# Altium schematic sheet Power_Sensing.SchDoc(Power_Sensing)
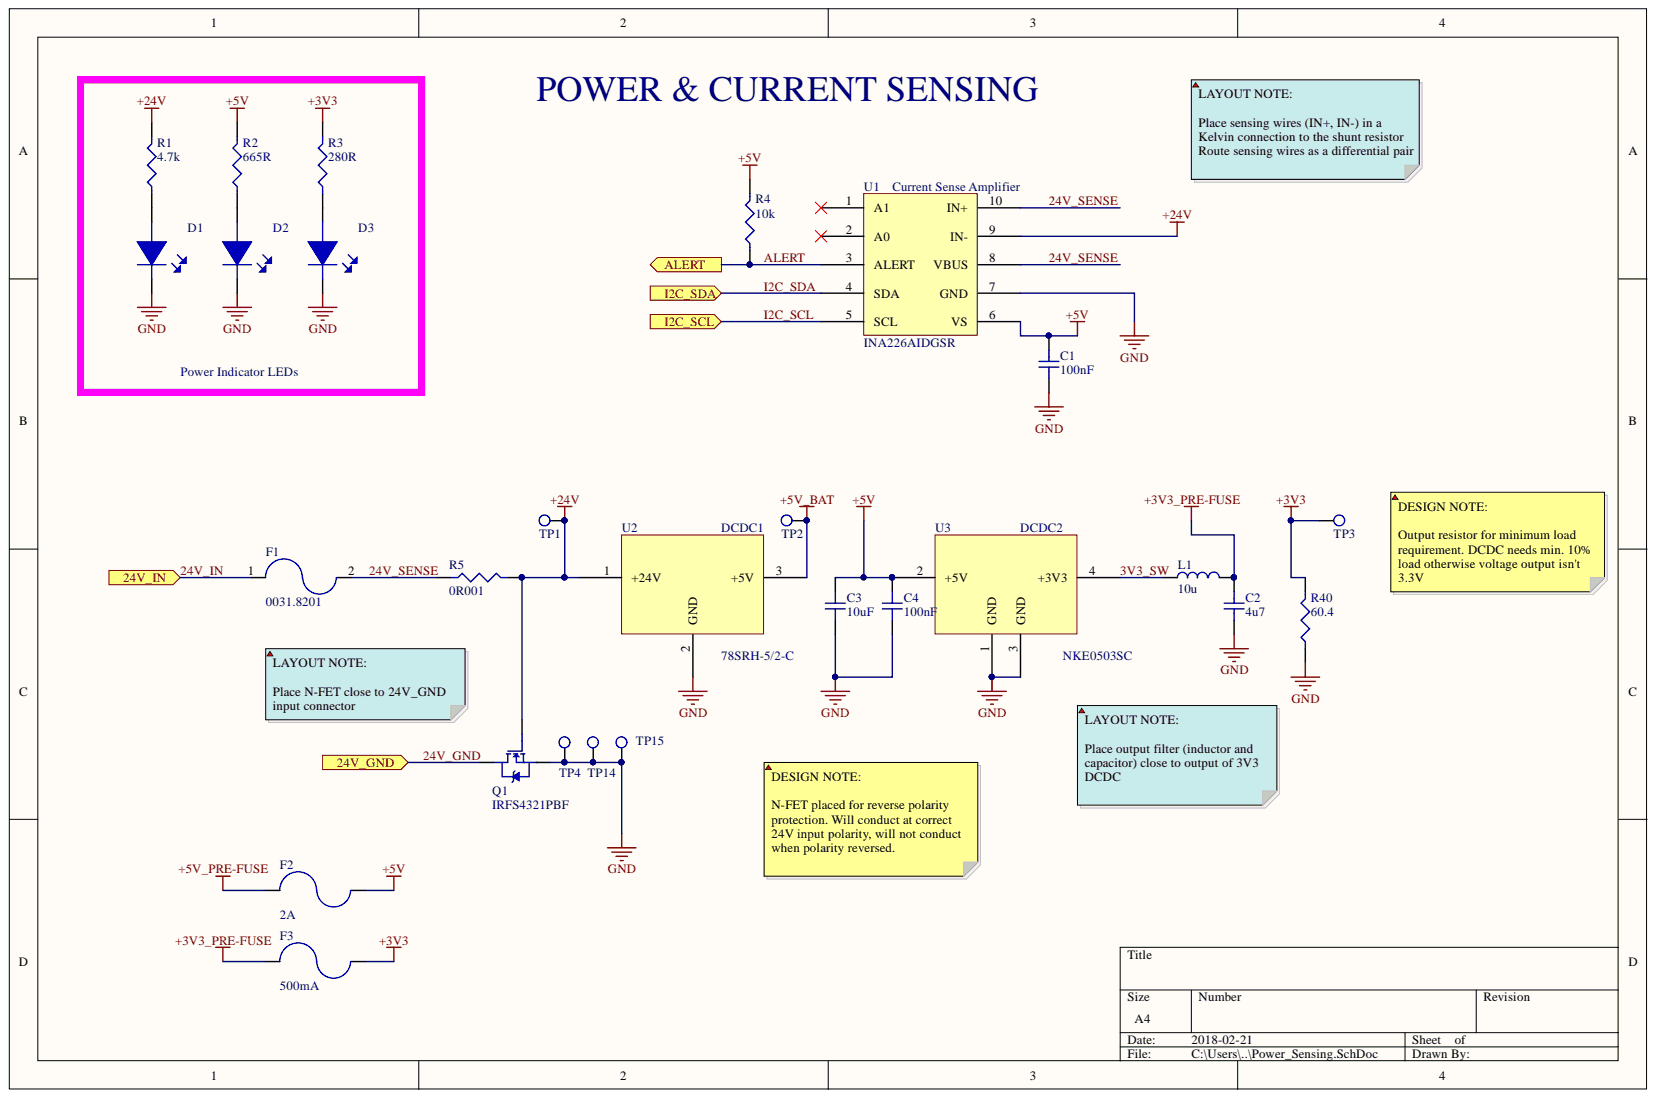
[diagram p0: Power_Sensing.SchDoc]

## Parts
C1  100nF  [C0805]
C2  4u7  [C0805]
C3  10uF  [TANT_10u]
C4  100nF  [C0805]
D1  LTST-C191KGKT  [LED_0603]  (value not drawn)
D2  LTST-C191KGKT  [LED_0603]  (value not drawn)
D3  LTST-C191KGKT  [LED_0603]  (value not drawn)
F1  0031.8201  [FUSE_HOLDER]
F2  2A  [FUSE_0603]
F3  500mA  [FUSE_0603]
L1  10u  [INDUCTOR]
Q1  IRFS4321PBF  [D2PAK_FETs]
R1  4.7k  [R0805]
R2  665R  [R0805]
R3  280R  [R0805]
R4  10k  [R0805]
R5  0R001  [R2512]
R40  60.4  [R0805]
TP1  5015  [TP_HOOK]  (value not drawn)
TP2  5015  [TP_HOOK]  (value not drawn)
TP3  5015  [TP_HOOK]  (value not drawn)
TP4  5015  [TP_HOOK]  (value not drawn)
TP14  5015  [TP_HOOK]  (value not drawn)
TP15  5015  [TP_HOOK]  (value not drawn)
U1  INA226AIDGSR  [10-VSSOP_INA226]
U2  78SRH-5/2-C  [DCDC1]
U3  NKE0503SC  [DCDC2]

## Ports
24V_GND, 24V_IN, ALERT, I2C_SCL, I2C_SDA

## Nets
+3V3: F3.2 R3.2 R40.2 TP3.1
+3V3_PRE-FUSE: C2.2 F3.1 L1.2
+5V: C1.2 C3.1 C4.2 F2.2 R2.2 R4.2 U1.6(VS) U3.2(+5V)
+5V_BAT: TP2.1 U2.3
+5V_PRE-FUSE: F2.1
+24V: Q1.1 R1.2 R5.2 TP1.1 U1.9(IN-) U2.1
GND: C1.1 C2.1 C3.2 C4.1 D1.2 D2.2 D3.2 Q1.3 R40.1 TP4.1 TP14.1 TP15.1 U1.7(GND) U2.2 U3.1(GND) U3.3(GND)
3V3_SW: L1.1 U3.4(+3V3)
24V_GND: Q1.4 ; port 24V_GND
24V_IN: F1.1 ; port 24V_IN
24V_SENSE: F1.2 R5.1 U1.8(VBUS) U1.10(IN+)
ALERT: R4.1 U1.3(ALERT) ; port ALERT
I2C_SCL: U1.5(SCL) ; port I2C_SCL
I2C_SDA: U1.4(SDA) ; port I2C_SDA
NetD1_1: D1.1 R1.1
NetD2_1: D2.1 R2.1
NetD3_1: D3.1 R3.1

Not connected: U1 1(A1) 2(A0)

## Library data of the parts
C1 C4 = GRM21B7U1A104JA01L: CAP MLCC 100nF 10Volts U2J 5%; Manufacturer: Murata; Voltage Rating (DC): 10V; Width: 0.049inch; Voltage Rating: 10V; Tolerance: 5%; Thickness: 0.053inch; Termination: SMD/SMT; Supplier Part Number 1: 490-11592-6-ND; Supplier 1: Digi-Key; Stock 1: 6000; Radiation Hardening: No; Pricing 1: 1=0.46, 10=0.32, 100=0.192, 500=0.144, 1000=0.1216 (USD); Packaging: Tape and Reel; Package Quantity: 3000; Mount: Surface Mount; Min Operating Temperature: -55°C; Max Operating Temperature: 125°C; Manufacturer Part Number: GRM21B7U1A104JA01L; Length: 2mm; Height: 1.25mm; Dielectric: U2J; Depth: 1.25mm; ComponentLink3URL: https://datasheet.ciiva.com/11618/product-11618924.pdf; ComponentLink3Description: https://datasheet.ciiva.com/11618/product-11618924.pdf; ComponentLink2URL: https://datasheet.ciiva.com/17451/product-17451845.pdf; ComponentLink2Description: https://datasheet.ciiva.com/17451/product-17451845.pdf; ComponentLink1URL: https://datasheet.ciiva.com/5424/product-5424660.pdf; ComponentLink1Description: https://datasheet.ciiva.com/5424/product-5424660.pdf; Component Kind: Standard; Case Code (Metric): 2012; Case Code (Imperial): 0805; Case/Package: 0805; Capacitance: 100nF; Library Name: PHANTOM_LIBRARY.SchLib
C2 = GRM219R61A475KE34D: CAP MLCC 4.7µF ±10% 10V X5R Ceramic Capacitor -55°C ~ 85°C Surface Mount, MLCC 0805 (2012 Metric) 0.079" L x 0.049" W (2.00mm x 1.25mm); Manufacturer: Murata; Capacitance: 4.7uF; Width: 0.049inch; Voltage Rating (DC): 10V; Voltage Rating: 10V; Voltage: 10V; Tolerance: 10%; Thickness: 0.037inch; Termination: SMD/SMT; Supplier Part Number 1: 490-3339-6-ND; Supplier 1: Digi-Key; Stock 1: 251830; Pricing 1: 1=0.21, 10=0.152, 100=0.0716, 500=0.0502, 1000=0.0416 (USD); Packaging: Tape and Reel; Package Quantity: 4000; Mount: Surface Mount; Min Operating Temperature: -55°C; Max Operating Temperature: 85°C; Manufacturer Part Number: GRM219R61A475KE34D; Length: 2mm; Lead Free: Lead Free; Height: 0.85mm; Dielectric: X5R; Depth: 1.25mm; ComponentLink5URL: https://datasheet.ciiva.com/29542/product-29542668.pdf; ComponentLink5Description: https://datasheet.ciiva.com/29542/product-29542668.pdf; ComponentLink4URL: https://datasheet.ciiva.com/29542/product-29542667.pdf; ComponentLink4Description: https://datasheet.ciiva.com/29542/product-29542667.pdf; ComponentLink3URL: https://datasheet.ciiva.com/16467/product-16467258.pdf; ComponentLink3Description: https://datasheet.ciiva.com/16467/product-16467258.pdf; ComponentLink2URL: https://datasheet.ciiva.com/5414/product-5414984.pdf; ComponentLink2Description: https://datasheet.ciiva.com/5414/product-5414984.pdf; ComponentLink1URL: https://datasheet.ciiva.com/169/product-169527.pdf; ComponentLink1Description: https://datasheet.ciiva.com/169/product-169527.pdf; Component Kind: Standard; Case Code (Metric): 2012; Case Code (Imperial): 0805; Case/Package: 0805; Library Name: PHANTOM_LIBRARY.SchLib
C3 = F381A106MMA: CAP TANTALUM 10uF, 0603 [1608 Metric], 0.5 ohm, 10 V AVX  Surface Mount Tantalum Capacitor, F38 Series, 10uF, 0603 [1608 Metric], 0.5 ohm, 10 V; Manufacturer: Kyocera AVX; Voltage Rating (DC): 10V; Tolerance: 20%; Life (Hours): 1000Hour; Leakage Current: 10uA; ESR (Equivalent Series Resistance): 500mR; Dissipation Factor: 15%; Width: 0.033inch; Weight: 7.1E-05oz; Voltage Rating: 10V; Termination: SMD/SMT; Supplier Part Number 1: 478-9527-1-ND; Supplier 1: Digi-Key; Stock 1: 7989; REACH SVHC: No SVHC; Radiation Hardening: No; Pricing 1: 1=0.75, 10=0.551, 100=0.3779, 500=0.2992, 1000=0.2519 (USD); Packaging: Tape and Reel; Package Quantity: 4000; Min Operating Temperature: -55°C; Max Operating Temperature: 105°C; Manufacturer Part Number: F381A106MMA; Length: 1.6mm; Height - Seated (Max): 0.035inch; Height: 0.8mm; Depth: 0.85mm; ComponentLink3URL: https://datasheet.ciiva.com/46417/1805432-46417518.pdf; ComponentLink3Description: https://datasheet.ciiva.com/46417/1805432-46417518.pdf; ComponentLink2URL: https://datasheet.ciiva.com/46417/1805432-46417517.pdf; ComponentLink2Description: https://datasheet.ciiva.com/46417/1805432-46417517.pdf; ComponentLink1URL: https://datasheet.ciiva.com/48510/f38-48510901.pdf; ComponentLink1Description: https://datasheet.ciiva.com/48510/f38-48510901.pdf; Component Kind: Standard; Case Code (Metric): 1608; Case Code (Imperial): 0603; Case/Package: 0603; Capacitance: 10uF; Library Name: PHANTOM_LIBRARY.SchLib
D1-D3 = LTST-C191KGKT: LED GREEN CLEAR 0603 SMD; Manufacturer: Vishay Lite-On; Wavelength: 574nm; Viewing Angle: 130°; Test Current: 20mA; Power Rating: 75mW; Number of LEDs: 1; Luminous Intensity: 35mcd; Lens Style: Clear,Diffused,Colorless,Rectangular; Lens Colour: Clear,Colorless; Forward Voltage: 2V; Forward Current: 30mA; Dominant Wavelength: 571nm; Width: 0.8mm; Supplier Part Number 1: 160-1446-6-ND; Supplier 1: Digi-Key; Stock 1: 1301664; Radiation Hardening: No; Pricing 1: 1=0.29, 10=0.211, 25=0.1556, 100=0.1111, 250=0.0756, 500=0.0667, 1000=0.0522, 2500=0.0511 (USD); Power Dissipation: 75mW; Packaging: Tape and Reel; Package Quantity: 1; Number of Pins: 2; Number of Elements: 1; Mount: Surface Mount; Min Operating Temperature: -55°C; Max Operating Temperature: 85°C; Manufacturer Part Number: LTST-C191KGKT; Lens Transparency: Clear; Length: 1.6mm; Lead Free: Lead Free; Illumination Colour: Green; Height: 0.55mm; Depth: 0.8mm; ComponentLink7URL: https://datasheet.ciiva.com/16215/ltst-c191kgkt-16215151.pdf; ComponentLink7Description: https://datasheet.ciiva.com/16215/ltst-c191kgkt-16215151.pdf; ComponentLink6URL: https://datasheet.ciiva.com/11773/0900766b80dc02b1-11773629.pdf; ComponentLink6Description: https://datasheet.ciiva.com/11773/0900766b80dc02b1-11773629.pdf; ComponentLink5URL: https://datasheet.ciiva.com/15223/getdatasheetpartid-103913-15223276.pdf; ComponentLink5Description: https://datasheet.ciiva.com/15223/getdatasheetpartid-103913-15223276.pdf; ComponentLink4URL: https://datasheet.ciiva.com/2109/s-110-ltst-c191kgkt-2109975.pdf; ComponentLink4Description: https://datasheet.ciiva.com/2109/s-110-ltst-c191kgkt-2109975.pdf; ComponentLink3URL: https://datasheet.ciiva.com/2109/s-110-ltst-c191kgkt-2109974.pdf; ComponentLink3Description: https://datasheet.ciiva.com/2109/s-110-ltst-c191kgkt-2109974.pdf; ComponentLink2URL: https://datasheet.ciiva.com/2109/s-110-ltst-c191kgkt-2109973.pdf; ComponentLink2Description: https://datasheet.ciiva.com/2109/s-110-ltst-c191kgkt-2109973.pdf; ComponentLink1URL: https://datasheet.ciiva.com/12400/100-ltst-c191kgkt-53586-12400818.pdf; ComponentLink1Description: https://datasheet.ciiva.com/12400/100-ltst-c191kgkt-53586-12400818.pdf; Component Kind: Standard; Colour: Green; Case Code (Metric): 1608; Case Code (Imperial): 0603; Case/Package: 0603; Library Name: PHANTOM_LIBRARY.SchLib
F1 = 0031.8201: FUSE HOLDER, PCB, 20X5MM; Manufacturer: Schurter; Voltage Rating (DC): 500V; Voltage Rating: 250V; Number of Poles: 1; Material: Tin,Thermoplastic; Insulation Resistance: 40MR; Illumination Type: Illuminated; Fuse Current: 10A; Colour: Black; Body Material: Thermoplastic; Approvals: UL,CSA,IEC,EN; Weight: 0.059966oz; Voltage Rating (AC): 500V; Voltage: 500V; Termination: PC Pin; Supplier Part Number 1: 486-1258-ND; Supplier 1: Digi-Key; Stock 1: 38678; REACH SVHC: No SVHC; Radiation Hardening: No; Pricing 1: 1=0.63, 10=0.589, 20=0.548, 50=0.4932, 100=0.4658, 200=0.4384, 500=0.411, 1000=0.3836, 5000=0.3644 (USD); Packaging: Bulk; Package Quantity: 100; Orientation: Horizontal; Number of Circuits: 1; Mount: Through Hole,PCB; Min Operating Temperature: -40°C; Max Operating Temperature: 85°C; Manufacturer Part Number: 0031.8201; Length: 25mm; Lead Free: Lead Free; Flammability Rating: UL94 V-0; Depth: 10.8mm; Current Rating: 10A; Contact Plating: Tin; Contact Material: Tin,Copper Alloy; ComponentLink7URL: https://datasheet.ciiva.com/25893/typ-ogn-25893934.pdf; ComponentLink7Description: https://datasheet.ciiva.com/25893/typ-ogn-25893934.pdf; ComponentLink6URL: https://datasheet.ciiva.com/16215/typ-ogn-16215099.pdf; ComponentLink6Description: https://datasheet.ciiva.com/16215/typ-ogn-16215099.pdf; ComponentLink5URL: https://datasheet.ciiva.com/16463/typ-ogn-16463850.pdf; ComponentLink5Description: https://datasheet.ciiva.com/16463/typ-ogn-16463850.pdf; ComponentLink4URL: https://datasheet.ciiva.com/16203/typ-ogn-16203626.pdf; ComponentLink4Description: https://datasheet.ciiva.com/16203/typ-ogn-16203626.pdf; ComponentLink3URL: https://datasheet.ciiva.com/15188/getdatasheetpartid-392104-15188042.pdf; ComponentLink3Description: https://datasheet.ciiva.com/15188/getdatasheetpartid-392104-15188042.pdf; ComponentLink2URL: https://datasheet.ciiva.com/1269/1842496-1269251.pdf; ComponentLink2Description: https://datasheet.ciiva.com/1269/1842496-1269251.pdf; ComponentLink1URL: https://datasheet.ciiva.com/3014/typ-ogn-3014480.pdf; ComponentLink1Description: https://datasheet.ciiva.com/3014/typ-ogn-3014480.pdf; Component Kind: Standard; Library Name: PHANTOM_LIBRARY.SchLib
F2 = 0603SFV200F/32-2: FUSE_2A SMD 0603 LITTELFUSE; Supplier Part Number 1: 0603SFV200F/32-2CT-ND; Supplier 1: Digi-Key; Stock 1: 17588; Pricing 1: 1=1.2, 5=1.166, 10=1.091, 50=0.9028, 100=0.7524, 250=0.7336, 500=0.6207, 1000=0.5455 (USD); Manufacturer Part Number: 0603SFV200F/32-2; Manufacturer: Littelfuse; Current Rating: 2A; ComponentLink1URL: //media.digikey.com/pdf/Data%20Sheets/Littelfuse%20PDFs/SMD_Fuses_Fundamentals.pdf; ComponentLink1Description: //media.digikey.com/pdf/Data%20Sheets/Littelfuse%20PDFs/SMD_Fuses_Fundamentals.pdf; Component Kind: Standard; Library Name: PHANTOM_LIBRARY.SchLib
F3 = ERB-RE0R50V: FUSE_0.5A SMD 0603, 0.5A; Manufacturer: Panasonic ECG; Voltage Rating (DC): 32V; Fuse Type: Board Mount (Cartridge Style Excluded); DC Cold Resistance: 330mR; Body Material: Alumina; Approvals: UL; Width: 0.8mm; Voltage Rating: 32V; Supplier Part Number 1: P15127CT-ND; Supplier 1: Digi-Key; Stock 1: 15715; REACH SVHC: No SVHC; Radiation Hardening: No; Pricing 1: 1=0.29, 5=0.284, 10=0.272, 50=0.2424, 100=0.2257, 250=0.2006, 500=0.1672, 1000=0.1588 (USD); Packaging: Tape and Reel; Package Quantity: 5000; Number of Pins: 2; Mount: Surface Mount; Min Operating Temperature: -40°C; Max Voltage Rating (DC): 32V; Max Operating Temperature: 125°C; Manufacturer Part Number: ERB-RE0R50V; Length: 1.6mm; Height: 0.54mm; Fuse Current: 500mA; Depth: 0.8mm; Current Rating: 500mA; Current: 500mA; ComponentLink9URL: https://datasheet.ciiva.com/46376/1328695-46376557.pdf; ComponentLink9Description: https://datasheet.ciiva.com/46376/1328695-46376557.pdf; ComponentLink8URL: https://datasheet.ciiva.com/30017/afa0000ce6-30017405.pdf; ComponentLink8Description: https://datasheet.ciiva.com/30017/afa0000ce6-30017405.pdf; ComponentLink7URL: https://datasheet.ciiva.com/46388/1328695-46388892.pdf; ComponentLink7Description: https://datasheet.ciiva.com/46388/1328695-46388892.pdf; ComponentLink6URL: https://datasheet.ciiva.com/5813/1328695-5813626.pdf; ComponentLink6Description: https://datasheet.ciiva.com/5813/1328695-5813626.pdf; ComponentLink5URL: https://datasheet.ciiva.com/11337/afa0000ce6-11337817.pdf; ComponentLink5Description: https://datasheet.ciiva.com/11337/afa0000ce6-11337817.pdf; ComponentLink4URL: https://datasheet.ciiva.com/25619/0900766b810c2f76-25619783.pdf; ComponentLink4Description: https://datasheet.ciiva.com/25619/0900766b810c2f76-25619783.pdf; ComponentLink3URL: https://datasheet.ciiva.com/299/afa0000ce6-299094.pdf; ComponentLink3Description: https://datasheet.ciiva.com/299/afa0000ce6-299094.pdf; ComponentLink2URL: https://datasheet.ciiva.com/299/afa0000ce6-299093.pdf; ComponentLink2Description: https://datasheet.ciiva.com/299/afa0000ce6-299093.pdf; ComponentLink1URL: https://datasheet.ciiva.com/299/afa0000ce6-299092.pdf; ComponentLink1Description: https://datasheet.ciiva.com/299/afa0000ce6-299092.pdf; Component Kind: Standard; Case Code (Metric): 1608; Case Code (Imperial): 0603; Case/Package: 0603; Library Name: PHANTOM_LIBRARY.SchLib
L1 = 82103C: Inductor Fixed 10uH 0.5A Mini SMT Power; Manufacturer: Murata; Tolerance: 10%; Test Frequency: 10KHz; Shielding: Unshielded; Self Resonant Frequency: 38MHz; Q Factor: 35; Max DC Current: 500mA; DC Resistance (DCR): 400mR; Width: 0.098inch; Termination: SMD/SMT; Supplier Part Number 1: 811-2473-6-ND; Supplier 1: Digi-Key; Stock 1: 1668; Radiation Hardening: No; Pricing 1: 1=0.32, 100=0.2906 (USD); Packaging: Tape and Reel; Package Quantity: 1; Mount: Surface Mount; Min Operating Temperature: -40°C; Military Standard: Not; Max Operating Temperature: 85°C; Manufacturer Part Number: 82103C; Length: 0.126inch; Inductance: 10uh; Height - Seated (Max): 0.092inch; Height: 2mm; Depth: 2.7mm; DC Current: 500mA; Current Rating: 500mA; Composition: Wirewound; ComponentLink3URL: https://datasheet.ciiva.com/6690/kmp-8200c-6690975.pdf; ComponentLink3Description: https://datasheet.ciiva.com/6690/kmp-8200c-6690975.pdf; ComponentLink2URL: https://datasheet.ciiva.com/6690/kmp-8200c-6690974.pdf; ComponentLink2Description: https://datasheet.ciiva.com/6690/kmp-8200c-6690974.pdf; ComponentLink1URL: https://datasheet.ciiva.com/6690/kmp-8200c-6690973.pdf; ComponentLink1Description: https://datasheet.ciiva.com/6690/kmp-8200c-6690973.pdf; Component Kind: Standard; Case Code (Metric): 3225; Case Code (Imperial): 1210; Case/Package: 1210; Library Name: PHANTOM_LIBRARY.SchLib
Q1 = IRFS4321PBF: MOSFET  N Channel, 83 A, 150 V, 0.012 ohm, 10 V, 5 V; Manufacturer: Infineon; Threshold Voltage: 5V; Rds On Max: 15mR; Max Power Dissipation: 350W; Input Capacitance: 4.46nF; Drain to Source Voltage (Vdss): 150V; Continuous Drain Current (ID): 85A; Supplier Part Number 1: IRFS4321PBF-ND; Supplier 1: Digi-Key; Stock 1: 1426; REACH SVHC: No SVHC; Pricing 1: 1=3.12, 10=2.804, 100=2.2973, 500=1.9556, 1000=1.6493 (USD); Packaging: Rail/Tube; Number of Pins: 3; Mount: Surface Mount; Min Operating Temperature: -55°C; Max Operating Temperature: 175°C; Manufacturer Part Number: IRFS4321PBF; Lead Free: Lead Free; ComponentLink1URL: http://www.farnell.com/datasheets/1308989.pdf; ComponentLink1Description: http://www.farnell.com/datasheets/1308989.pdf; Component Kind: Standard; Case/Package: TO-263-3; Library Name: PHANTOM_LIBRARY.SchLib
R1 = RC0805FR-074K7L: RES SMD 4.7K OHM 1% 1/8W 0805; Manufacturer: Yageo; Voltage Rating (DC): 150V; Temperature Coefficient: 100ppm/°C; Resistance: 4.7KR; Power Rating: 125mW; Width: 0.049inch; Voltage Rating: 150V; Tolerance: 1%; Termination: SMD/SMT; Supplier Part Number 1: 311-4.70KCRDKR-ND; Supplier 1: Digi-Key; Stock 1: 2632902; REACH SVHC: No SVHC; Pricing 1: 1=0.1, 10=0.022, 100=0.0088, 1000=0.004, 2500=0.0034 (USD); Packaging: Tape and Reel; Package Quantity: 5000; Number of Terminations: 2; Number of Pins: 2; Min Operating Temperature: -55°C; Military Standard: Not; Max Power Dissipation: 125mW; Max Operating Temperature: 155°C; Manufacturer Part Number: RC0805FR-074K7L; Length: 0.079inch; Lead Free: Lead Free; Height: 0.024inch; Depth: 1.25mm; Composition: Thick Film; ComponentLink6URL: https://datasheet.ciiva.com/46447/444164-46447583.pdf; ComponentLink6Description: https://datasheet.ciiva.com/46447/444164-46447583.pdf; ComponentLink5URL: https://datasheet.ciiva.com/6547/pyu-r-int-thick-7-6547263.pdf; ComponentLink5Description: https://datasheet.ciiva.com/6547/pyu-r-int-thick-7-6547263.pdf; ComponentLink4URL: https://datasheet.ciiva.com/7941/444164-7941806.pdf; ComponentLink4Description: https://datasheet.ciiva.com/7941/444164-7941806.pdf; ComponentLink3URL: https://datasheet.ciiva.com/7941/1686128-7941805.pdf; ComponentLink3Description: https://datasheet.ciiva.com/7941/1686128-7941805.pdf; ComponentLink2URL: https://datasheet.ciiva.com/7941/444164-7941804.pdf; ComponentLink2Description: https://datasheet.ciiva.com/7941/444164-7941804.pdf; ComponentLink1URL: https://datasheet.ciiva.com/11808/0900766b8106beab-11808155.pdf; ComponentLink1Description: https://datasheet.ciiva.com/11808/0900766b8106beab-11808155.pdf; Component Kind: Standard; Case Code (Metric): 2012; Case Code (Imperial): 0805; Case/Package: 0805; Library Name: PHANTOM_LIBRARY.SchLib
R2 = RC0805FR-07665RL: RES SMD 665 OHM 1% 1/8W 0805; Manufacturer: Yageo; Voltage Rating (DC): 150V; Temperature Coefficient: 100ppm/°C; Power Rating: 125mW; Width: 1.25mm; Voltage Rating: 150V; Tolerance: 1%; Termination: SMD/SMT; Supplier Part Number 1: 311-665CRDKR-ND; Supplier 1: Digi-Key; Stock 1: 51898; Resistance: 665R; Pricing 1: 1=0.1, 10=0.022, 100=0.0088, 1000=0.004, 2500=0.0034 (USD); Packaging: Tape and Reel; Package Quantity: 1; Number of Terminations: 2; Min Operating Temperature: -55°C; Military Standard: Not; Max Power Dissipation: 125mW; Max Operating Temperature: 155°C; Manufacturer Part Number: RC0805FR-07665RL; Length: 2mm; Lead Free: Lead Free; Height: 0.024inch; Depth: 1.25mm; Composition: Thick Film; ComponentLink5URL: https://datasheet.ciiva.com/2457/pyu-r-int-thick-7-2457790.pdf; ComponentLink5Description: https://datasheet.ciiva.com/2457/pyu-r-int-thick-7-2457790.pdf; ComponentLink4URL: https://datasheet.ciiva.com/2457/pyu-r-int-thick-7-2457789.pdf; ComponentLink4Description: https://datasheet.ciiva.com/2457/pyu-r-int-thick-7-2457789.pdf; ComponentLink3URL: https://datasheet.ciiva.com/2457/pyu-r-int-thick-7-2457788.pdf; ComponentLink3Description: https://datasheet.ciiva.com/2457/pyu-r-int-thick-7-2457788.pdf; ComponentLink2URL: https://datasheet.ciiva.com/12561/rc0805-257173-12561528.pdf; ComponentLink2Description: https://datasheet.ciiva.com/12561/rc0805-257173-12561528.pdf; ComponentLink1URL: https://datasheet.ciiva.com/17423/rc0805-17423095.pdf; ComponentLink1Description: https://datasheet.ciiva.com/17423/rc0805-17423095.pdf; Component Kind: Standard; Case Code (Metric): 2012; Case Code (Imperial): 0805; Case/Package: 0805; Library Name: PHANTOM_LIBRARY.SchLib
R3 = RC0805FR-07280RL: RES SMD 280 OHM 1% 1/8W 0805; Manufacturer: Yageo; Voltage Rating (DC): 150V; Temperature Coefficient: 100ppm/°C; Power Rating: 125mW; Width: 1.25mm; Voltage Rating: 150V; Tolerance: 1%; Termination: SMD/SMT; Supplier Part Number 1: 311-280CRCT-ND; Supplier 1: Digi-Key; Stock 1: 117224; Resistance: 280R; Pricing 1: 1=0.1, 10=0.022, 100=0.0088, 1000=0.004, 2500=0.0034 (USD); Packaging: Tape and Reel; Package Quantity: 1; Number of Terminations: 2; Min Operating Temperature: -55°C; Military Standard: Not; Max Power Dissipation: 125mW; Max Operating Temperature: 155°C; Manufacturer Part Number: RC0805FR-07280RL; Length: 2mm; Lead Free: Lead Free; Height: 0.024inch; Depth: 1.25mm; Composition: Thick Film; ComponentLink5URL: https://datasheet.ciiva.com/2457/pyu-r-int-thick-7-2457391.pdf; ComponentLink5Description: https://datasheet.ciiva.com/2457/pyu-r-int-thick-7-2457391.pdf; ComponentLink4URL: https://datasheet.ciiva.com/2457/pyu-r-int-thick-7-2457390.pdf; ComponentLink4Description: https://datasheet.ciiva.com/2457/pyu-r-int-thick-7-2457390.pdf; ComponentLink3URL: https://datasheet.ciiva.com/2457/pyu-r-int-thick-7-2457389.pdf; ComponentLink3Description: https://datasheet.ciiva.com/2457/pyu-r-int-thick-7-2457389.pdf; ComponentLink2URL: https://datasheet.ciiva.com/17423/rc0805-17423226.pdf; ComponentLink2Description: https://datasheet.ciiva.com/17423/rc0805-17423226.pdf; ComponentLink1URL: https://datasheet.ciiva.com/12558/rc0805-257173-12558854.pdf; ComponentLink1Description: https://datasheet.ciiva.com/12558/rc0805-257173-12558854.pdf; Component Kind: Standard; Case Code (Metric): 2012; Case Code (Imperial): 0805; Case/Package: 0805; Library Name: PHANTOM_LIBRARY.SchLib
R4 = RC0805FR-071KL: RES SMD 10K OHM 1% 1/8W 0805; Manufacturer: Yageo Phycomp; Voltage Rating (DC): 150V; Temperature Coefficient: 100ppm/°C; Resistance: 1KR; Power Rating: 125mW; Width: 1.25mm; Tolerance: 1%; Supplier 1: Digi-Key; Stock 1: 5527973; REACH SVHC: No SVHC; Pricing 1: 1=0.1, 10=0.022, 100=0.0088, 1000=0.004, 2500=0.0034 (USD); Packaging: Cut Tape; Number of Pins: 2; Min Operating Temperature: -55°C; Max Operating Temperature: 155°C; Manufacturer Part Number: RC0805FR-071KL; Length: 2mm; Lead Free: Lead Free; Height: 0.5mm; Depth: 1.25mm; Component Kind: Standard; Case/Package: 0805; Library Name: PHANTOM_LIBRARY.SchLib
R5 = ERJ-M1WTF1M0U: Res Thick Film 2512 0.001 Ohm 1% 1W 250ppm/C to 450ppm/C Molded SMD Embossed Carrier T/R; Manufacturer: Panasonic ECG; Temperature Coefficient: 450ppm/°C; Resistance: 1mR; Width: 0.126inch; Tolerance: 1%; Termination: SMD/SMT; Supplier Part Number 1: P1.0NDCT-ND; Supplier 1: Digi-Key; Stock 1: 36740; REACH SVHC: No SVHC; Radiation Hardening: No; Pricing 1: 1=0.55, 10=0.456, 25=0.3908, 50=0.3516, 100=0.3061, 250=0.2735, 500=0.2214, 1000=0.1791 (USD); Power Rating: 1W; Packaging: Tape and Reel; Package Quantity: 1; Number of Terminations: 2; Number of Pins: 2; Mount: Surface Mount; Min Operating Temperature: -55°C; Military Standard: Not; Max Power Dissipation: 1W; Max Operating Temperature: 170°C; Manufacturer Part Number: ERJ-M1WTF1M0U; Length: 6.4mm; Lead Free: Lead Free; Height: 0.8mm; Depth: 3.2mm; Contact Plating: Tin; Composition: Thick Film,Metal Strip,Metal Element; ComponentLink11URL: https://datasheet.ciiva.com/16785/70260812-16785566.pdf; ComponentLink11Description: https://datasheet.ciiva.com/16785/70260812-16785566.pdf; ComponentLink10URL: https://datasheet.ciiva.com/30206/aoa0000ce7-30206797.pdf; ComponentLink10Description: https://datasheet.ciiva.com/30206/aoa0000ce7-30206797.pdf; ComponentLink9URL: https://datasheet.ciiva.com/17215/aoa0000ce7-17215229.pdf; ComponentLink9Description: https://datasheet.ciiva.com/17215/aoa0000ce7-17215229.pdf; ComponentLink8URL: https://datasheet.ciiva.com/5996/39800-5996507.pdf; ComponentLink8Description: https://datasheet.ciiva.com/5996/39800-5996507.pdf; ComponentLink7URL: https://datasheet.ciiva.com/16788/70260812-16788394.pdf; ComponentLink7Description: https://datasheet.ciiva.com/16788/70260812-16788394.pdf; ComponentLink6URL: https://datasheet.ciiva.com/16512/aoa0000ce7-16512553.pdf; ComponentLink6Description: https://datasheet.ciiva.com/16512/aoa0000ce7-16512553.pdf; ComponentLink5URL: https://datasheet.ciiva.com/24072/aoa0000ce7-60136-24072248.pdf; ComponentLink5Description: https://datasheet.ciiva.com/24072/aoa0000ce7-60136-24072248.pdf; ComponentLink4URL: https://datasheet.ciiva.com/16216/aoa0000ce7-16216983.pdf; ComponentLink4Description: https://datasheet.ciiva.com/16216/aoa0000ce7-16216983.pdf; ComponentLink3URL: https://datasheet.ciiva.com/7777/39800-7777943.pdf; ComponentLink3Description: https://datasheet.ciiva.com/7777/39800-7777943.pdf; ComponentLink2URL: https://datasheet.ciiva.com/9198/aoa0000ce7-9198885.pdf; ComponentLink2Description: https://datasheet.ciiva.com/9198/aoa0000ce7-9198885.pdf; ComponentLink1URL: https://datasheet.ciiva.com/11811/0900766b80befc3b-11811491.pdf; ComponentLink1Description: https://datasheet.ciiva.com/11811/0900766b80befc3b-11811491.pdf; Component Kind: Standard; Case Code (Metric): 6432; Case Code (Imperial): 2512; Case/Package: 2512; Library Name: PHANTOM_LIBRARY.SchLib
R40 = RC0805FR-0760R4L: RES SMD 60.4 OHM 1% 1/8W 0805; Manufacturer: Yageo; Voltage Rating (DC): 150V; Temperature Coefficient: 100ppm/°C; Resistance: 60.4R; Power Rating: 125mW; Width: 0.049inch; Voltage Rating: 150V; Tolerance: 1%; Termination: SMD/SMT; Supplier Part Number 1: 311-60.4CRDKR-ND; Supplier 1: Digi-Key; Stock 1: 189238; Radiation Hardening: No; Pricing 1: 1=0.1, 10=0.022, 100=0.0088, 1000=0.004, 2500=0.0034 (USD); Packaging: Tape and Reel; Package Quantity: 1; Number of Terminations: 2; Min Operating Temperature: -55°C; Military Standard: Not; Max Power Dissipation: 125mW; Max Operating Temperature: 155°C; Manufacturer Part Number: RC0805FR-0760R4L; Length: 0.079inch; Lead Free: Lead Free; Height: 0.024inch; Depth: 1.25mm; Contact Plating: Tin,Lead,Matte; Composition: Thick Film; ComponentLink3URL: https://datasheet.ciiva.com/2457/pyu-r-int-thick-7-2457215.pdf; ComponentLink3Description: https://datasheet.ciiva.com/2457/pyu-r-int-thick-7-2457215.pdf; ComponentLink2URL: https://datasheet.ciiva.com/2457/pyu-r-int-thick-7-2457217.pdf; ComponentLink2Description: https://datasheet.ciiva.com/2457/pyu-r-int-thick-7-2457217.pdf; ComponentLink1URL: https://datasheet.ciiva.com/2457/pyu-r-int-thick-7-2457216.pdf; ComponentLink1Description: https://datasheet.ciiva.com/2457/pyu-r-int-thick-7-2457216.pdf; Component Kind: Standard; Case Code (Metric): 2012; Case Code (Imperial): 0805; Case/Package: 0805; Library Name: PHANTOM_LIBRARY.SchLib
TP1-TP4 TP14 TP15 = 5015: TEST POINT, PCB, SMT; Manufacturer: Keystone Electronics; Number of Ports: 1; Thickness: 0.01inch; Termination: Solder; Supplier Part Number 1: 36-5015CT-ND; Supplier 1: Digi-Key; Stock 1: 1937775; REACH SVHC: No SVHC; Pricing 1: 1=0.44, 10=0.421, 25=0.3604, 50=0.3064, 100=0.2944, 250=0.2644, 500=0.2524 (USD); Packaging: Tape and Reel; Package Quantity: 1; Orientation: Straight; Mount: Surface Mount; Material: Bronze,Silver; Manufacturer Part Number: 5015; Length: 2.66mm; Lead Free: Lead Free; Height: 0.06inch; Depth: 1.01mm; Contact Plating: Tin,Silver,Lead; Contact Material: Bronze; ComponentLink4URL: https://datasheet.ciiva.com/16883/70182998-16883734.pdf; ComponentLink4Description: https://datasheet.ciiva.com/16883/70182998-16883734.pdf; ComponentLink3URL: https://datasheet.ciiva.com/1123/5015-1123862.pdf; ComponentLink3Description: https://datasheet.ciiva.com/1123/5015-1123862.pdf; ComponentLink2URL: https://datasheet.ciiva.com/2917/5015-2917617.pdf; ComponentLink2Description: https://datasheet.ciiva.com/2917/5015-2917617.pdf; ComponentLink1URL: https://datasheet.ciiva.com/2917/5015-2917616.pdf; ComponentLink1Description: https://datasheet.ciiva.com/2917/5015-2917616.pdf; Component Kind: Standard; Colour: White; Library Name: PHANTOM_LIBRARY.SchLib
U1 = INA226AIDGSR: IC MONITOR PWR/CURR BIDIR 10MSOP; Manufacturer: Texas Instruments; Output Current: 10mA; Nominal Supply Current: 330uA; Min Supply Voltage: 2.7V; Max Supply Voltage: 5.5V; Input Offset Voltage (Vos): 2.5uV; Input Bias Current: 10uA; Common Mode Rejection Ratio: 140dB; Accuracy: 0.02%; Supplier Part Number 1: 296-29034-1-ND; Supplier 1: Digi-Key; Stock 1: 62674; REACH SVHC: No SVHC; Radiation Hardening: No; Pricing 1: 1=2.95, 10=2.648, 100=2.1692, 500=1.8466, 1000=1.5574 (USD); Packaging: Tape and Reel; Package Quantity: 1; Number of Pins: 10; Mount: Surface Mount; Min Operating Temperature: -40°C; Max Operating Temperature: 125°C; Manufacturer Part Number: INA226AIDGSR; Lead Free: Lead Free; Contact Plating: Gold; ComponentLink6URL: https://datasheet.ciiva.com/22693/1840205-22693516.pdf; ComponentLink6Description: https://datasheet.ciiva.com/22693/1840205-22693516.pdf; ComponentLink5URL: https://datasheet.ciiva.com/4875/sbos547-126280-4875559.pdf; ComponentLink5Description: https://datasheet.ciiva.com/4875/sbos547-126280-4875559.pdf; ComponentLink4URL: https://datasheet.ciiva.com/14196/ina226-14196741.pdf; ComponentLink4Description: https://datasheet.ciiva.com/14196/ina226-14196741.pdf; ComponentLink3URL: https://datasheet.ciiva.com/1939/ina226-1939635.pdf; ComponentLink3Description: https://datasheet.ciiva.com/1939/ina226-1939635.pdf; ComponentLink2URL: https://datasheet.ciiva.com/1939/ina226-1939634.pdf; ComponentLink2Description: https://datasheet.ciiva.com/1939/ina226-1939634.pdf; ComponentLink1URL: https://datasheet.ciiva.com/1939/ina226-1939633.pdf; ComponentLink1Description: https://datasheet.ciiva.com/1939/ina226-1939633.pdf; Component Kind: Standard; Case/Package: VSSOP; Library Name: PHANTOM_LIBRARY.SchLib
U2 = 78SRH-5/2-C: DCDC 5V MURATA POWER SOLUTIONS 	   	78SRH-5/2-C 	   	IC, SYNC SWITCHING REGULATOR, TO-220-3; Output Power: 10W; Output Current: 2A; Number of Outputs: 1; Nominal Input Voltage: 12V; Min Input Voltage (DC): 8V; Max Input Voltage (DC): 32V; Isolation: Isolated; Efficiency: 95%; Width: 0.8inch; Weight: 1lb; Voltage - Output 1: 5V; Supplier Part Number 1: 811-2657-ND; Supplier 1: Digi-Key; Stock 1: 2978; REACH SVHC: No SVHC; Radiation Hardening: No; Pricing 1: 1=13.95, 5=12.2, 10=10.959 (USD); Packaging: Box; Package Quantity: 144; Output Voltage: 5V; Number of Pins: 3; Nominal Output Voltage: 5V; Mount: Through Hole; Min Operating Temperature: -40°C; Min Input Voltage: 8V; Max Power Dissipation: 10W; Max Output Power: 10W; Max Output Current: 2A; Max Operating Temperature: 70°C; Max Input Voltage: 32V; Manufacturer Part Number: 78SRH-5/2-C; Manufacturer: Murata; Length: 20.3mm; Lead Free: Lead Free; Height: 0.28inch; Depth: 7.1mm; Current - Output 1: 2A; ComponentLink5URL: https://datasheet.ciiva.com/16405/mpm-78sr-2a-a00-16405759.pdf; ComponentLink5Description: https://datasheet.ciiva.com/16405/mpm-78sr-2a-a00-16405759.pdf; ComponentLink4URL: https://datasheet.ciiva.com/16627/mpm-78sr-2a-a00-16627849.pdf; ComponentLink4Description: https://datasheet.ciiva.com/16627/mpm-78sr-2a-a00-16627849.pdf; ComponentLink3URL: https://datasheet.ciiva.com/26809/getdatasheetpartid-357559-26809336.pdf; ComponentLink3Description: https://datasheet.ciiva.com/26809/getdatasheetpartid-357559-26809336.pdf; ComponentLink2URL: https://datasheet.ciiva.com/1170/698935-1170225.pdf; ComponentLink2Description: https://datasheet.ciiva.com/1170/698935-1170225.pdf; ComponentLink1URL: https://datasheet.ciiva.com/3240/mpm-78sr-2a-a00-3240576.pdf; ComponentLink1Description: https://datasheet.ciiva.com/3240/mpm-78sr-2a-a00-3240576.pdf; Component Kind: Standard; Case/Package: SIP; Library Name: PHANTOM_LIBRARY.SchLib
U3 = NKE0503SC: DCDC 3V3 CONVERTER 3.3V 1W; Manufacturer: Murata; Output Current: 303mA; Number of Outputs: 1; Nominal Input Voltage: 5V; Min Input Voltage (DC): 4.5V; Max Input Voltage (DC): 5.5V; Isolation Voltage: 3kV; Isolation: Isolated; Efficiency: 74%; Width: 6mm; Voltage - Output 1: 3.3V; Supplier Part Number 1: 811-1432-5-ND; Supplier 1: Digi-Key; Stock 1: 748; REACH SVHC: No SVHC; Radiation Hardening: No; Pricing 1: 1=7.61, 5=7.57, 10=7.52, 25=7.43, 50=7.25, 100=6.89, 250=6.45, 500=6.01, 1000=5.985 (USD); Packaging: Rail/Tube; Package Quantity: 40; Output Voltage: 3.3V; Output Power: 1W; Number of Pins: 4; Nominal Output Voltage: 3.3V; Mount: Through Hole; Min Operating Temperature: -40°C; Min Input Voltage: 4.5V; Max Power Dissipation: 1W; Max Output Power: 1W; Max Output Current: 303mA; Max Operating Temperature: 85°C; Max Input Voltage: 5.5V; Manufacturer Part Number: NKE0503SC; Length: 11.48mm; Height: 7.46mm; Flammability Rating: UL94 V-0; Depth: 6mm; Current - Output 1: 303mA; ComponentLink5URL: https://datasheet.ciiva.com/29186/158161-da-01-en-dc-dc-wandler-nke0503sc-mps-29186131.pdf; ComponentLink5Description: https://datasheet.ciiva.com/29186/158161-da-01-en-dc-dc-wandler-nke0503sc-mps-29186131.pdf; ComponentLink4URL: https://datasheet.ciiva.com/8011/1790387-8011754.pdf; ComponentLink4Description: https://datasheet.ciiva.com/8011/1790387-8011754.pdf; ComponentLink3URL: https://datasheet.ciiva.com/2169/kdc-nke-2169402.pdf; ComponentLink3Description: https://datasheet.ciiva.com/2169/kdc-nke-2169402.pdf; ComponentLink2URL: https://datasheet.ciiva.com/16627/kdc-nke-16627961.pdf; ComponentLink2Description: https://datasheet.ciiva.com/16627/kdc-nke-16627961.pdf; ComponentLink1URL: https://datasheet.ciiva.com/18018/getdatasheetpartid-363088-18018901.pdf; ComponentLink1Description: https://datasheet.ciiva.com/18018/getdatasheetpartid-363088-18018901.pdf; Component Kind: Standard; Case/Package: SIP; Library Name: PHANTOM_LIBRARY.SchLib
